# Altium schematic sheet SDCard.SchDoc(SDCard)
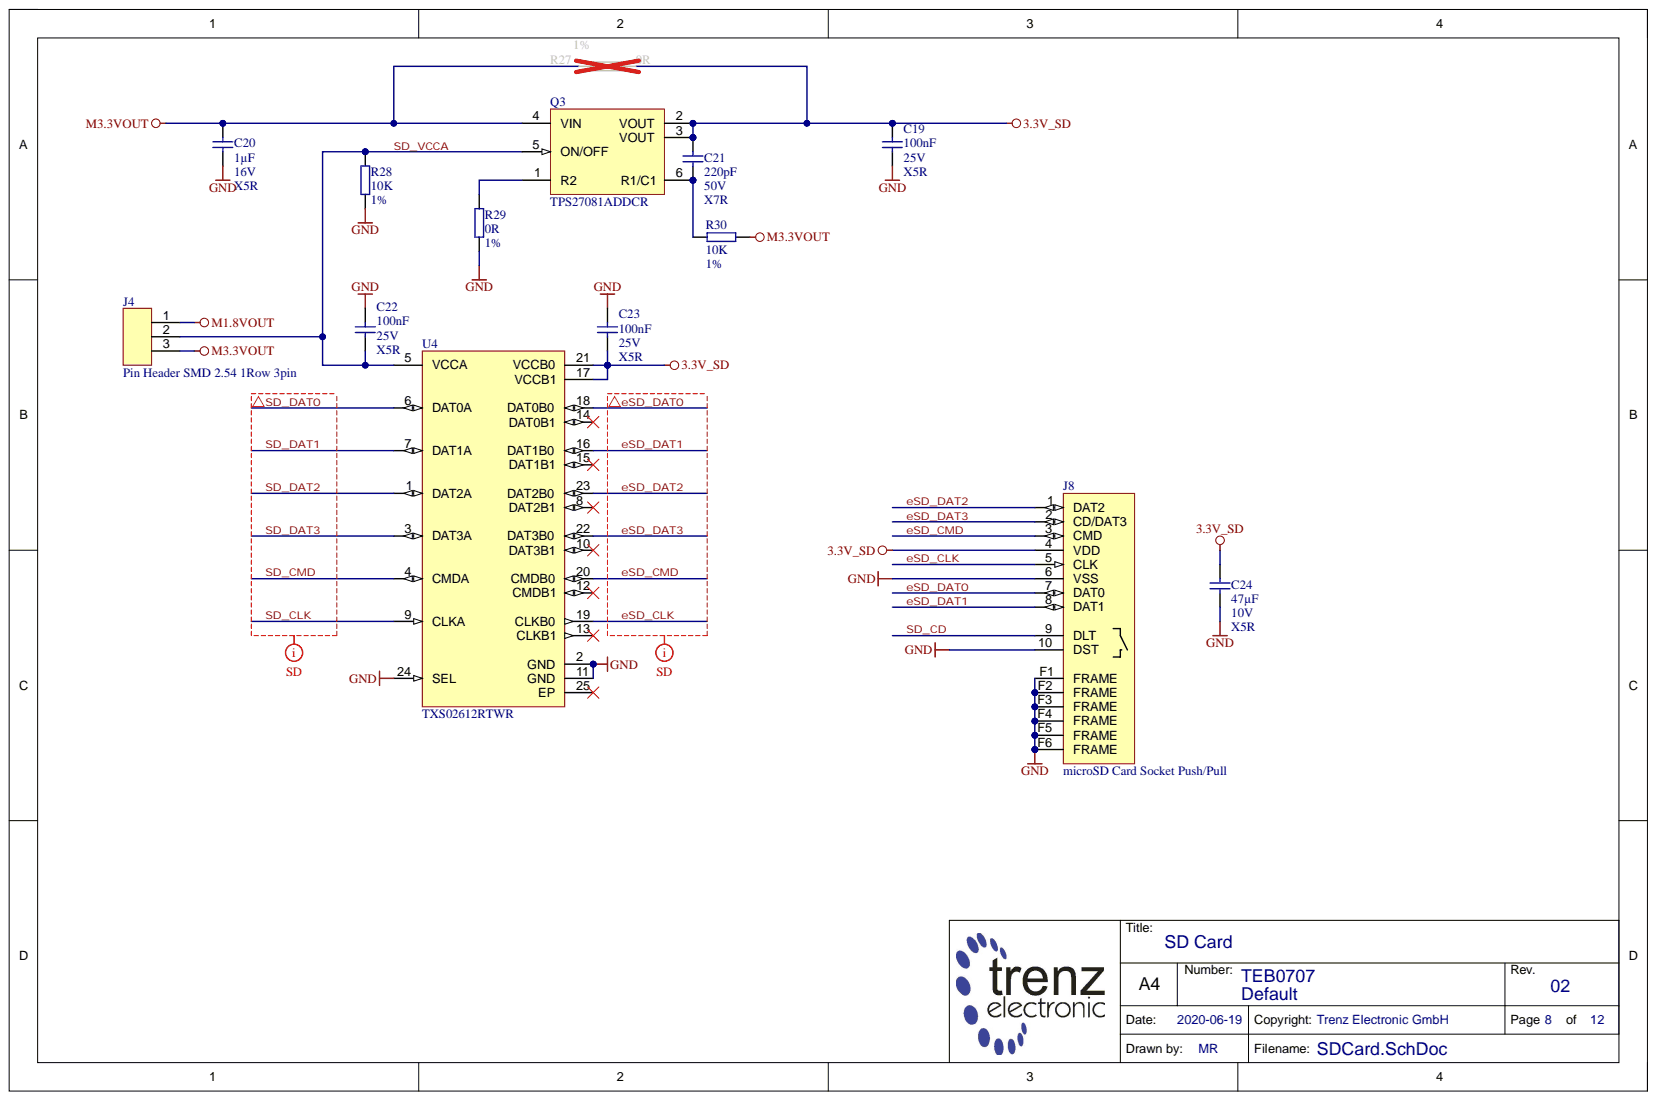
[diagram p0: SDCard.SchDoc]

## Parts
C19  100nF  Technology: X5R  Voltage: 25V  [CAPC1005X55N]
C20  1µF  Technology: X5R  Voltage: 16V  [CAPC1005X55N_V]
C21  220pF  Voltage: 50V  [CAPC1005X55N]
C22  100nF  Technology: X5R  Voltage: 25V  [CAPC1005X55N]
C23  100nF  Technology: X5R  Voltage: 25V  [CAPC1005X55N]
C24  47µF  Technology: X5R  Voltage: 10V  [CAPC2012X135N]
J4  Pin Header SMD 2.54 1Row 3pin  [TSM-103-01-F-SV]
J8  microSD Card Socket Push/Pull  Case: microSD  [504077-1891]
Q3  TPS27081ADDCR  [SOT95P280X110-6N]
R27  0R  Tolerance: 1%  [RESC2012X60N]
R28  10K  Tolerance: 1%  [RESC1005X40N]
R29  0R  Tolerance: 1%  [RESC1005X40N]
R30  10K  Tolerance: 1%  [RESC1005X40N]
U4  TXS02612RTWR  [QFN50P400X400X80-25N-255]

## Nets
3.3V_SD: C19.2 C21.2 C23.1 C24.2 J8.4(VDD) Q3.2(VOUT) Q3.3(VOUT) R27.2 U4.17(VCCB1) U4.21(VCCB0)
GND: C19.1 C20.2 C22.2 C23.2 C24.1 J8.6(VSS) J8.10(DST) J8.F1(FRAME) J8.F2(FRAME) J8.F3(FRAME) J8.F4(FRAME) J8.F5(FRAME) J8.F6(FRAME) R28.2 R29.1 U4.2(GND) U4.11(GND) U4.24(SEL)
M1.8VOUT: J4.1
M3.3VOUT: C20.1 J4.3 Q3.4(VIN) R27.1 R30.2
eSD_CLK: J8.5(CLK) U4.19(CLKB0)
eSD_CMD: J8.3(CMD) U4.20(CMDB0)
eSD_DAT0: J8.7(DAT0) U4.18(DAT0B0)
eSD_DAT1: J8.8(DAT1) U4.16(DAT1B0)
eSD_DAT2: J8.1(DAT2) U4.23(DAT2B0)
eSD_DAT3: J8.2(CD/DAT3) U4.22(DAT3B0)
SD_CD: J8.9(DLT)
SD_CLK: U4.9(CLKA)
SD_CMD: U4.4(CMDA)
SD_DAT0: U4.6(DAT0A)
SD_DAT1: U4.7(DAT1A)
SD_DAT2: U4.1(DAT2A)
SD_DAT3: U4.3(DAT3A)
SD_VCCA: C22.1 J4.2 Q3.5(ON/OFF) R28.1 U4.5(VCCA)
NetC21_1: C21.1 Q3.6(R1/C1) R30.1
NetQ3_1: Q3.1(R2) R29.2

Not connected: U4 8(DAT2B1) 10(DAT3B1) 12(CMDB1) 13(CLKB1) 14(DAT0B1) 15(DAT1B1) 25(EP)

## Library data of the parts
C19 C22 C23 = 22472: KON 100nF 25V X5R ±10% SMD BF 1005 (0402); Database Table Name: C_1005_0402; Mixed: SMT; Preferred: Yes; Temperature-Range: -55/+85; Value: 100n; AN: 22472; Case: 1005 (0402); Component Kind: Standard; Library Name: TE.DbLib
C20 = 26579: KON 1µF 16V X5R 10% SMD BF 1005 (0402); Database Table Name: C_1005_0402; Mixed: SMT; Temperature-Range: -55/+85; Value: 1u; AN: 26579; Case: 1005 (0402); Component Kind: Standard; Library Name: TE.DbLib; Tolerance: 10%
C21 = 24207: KON 220pF 50V X7R 10%  SMD BF 1005 (0402); Database Table Name: C_1005_0402; Mixed: SMT; Preferred: Yes; Temperature-Range: -55/+125; Value: 220p; AN: 24207; Case: 1005 (0402); Component Kind: Standard; Library Name: TE.DbLib; Technology: X7R; Tolerance: 10%
C24 = 26515: KON 47µF 10V  X5R 20% SMD BF 2012 (0805); Database Table Name: C_2012_0805; Mixed: SMT; Temperature-Range: -55/+85; Value: 47u; AN: 26515; Case: 2012 (0805); Component Kind: Standard; Library Name: TE.DbLib
J4 = 29447: Stiftleiste 1-reihig, 3pol. SMD; Database Table Name: CON_HEADER; Extra: 2,54mm, 5.84mm pin length, gold flash, PP pad; H-ART: TSM-103-01-F-SV-P-TR; Mixed: SMT; Temperature-Range: -55/+125; AN: 29447; Case: TSM; Component Kind: Standard; Library Name: TE.DbLib; HelpURL: b:\AD\adlib\datasheet\Samtec_TSM\
J8 = 29290: microSD Card Socket with Detect Switch; Extra: Molex 504077-1891; Mixed: SMT; Temperature-Range: -40/+85; AN: 29290; Component Kind: Standard; Database Table Name: SOCKET; H-ART: 504077-1891; Library Name: TE.DbLib; HelpURL: b:\AD\adlib\datasheet\504077-1891\
Q3 = 29262: Database Table Name: SWITCH; Extra: 1.2V - 8V, 3A PFET High-Side Load Switch; Mixed: SMT; Temperature-Range: -40/+85; AN: 29262; Case: SOT23-6; Component Kind: Standard; Description: TPS27081ADDCR; H-ART: TPS27081ADDCR; Library Name: TE.DbLib; HelpURL: b:\AD\adlib\datasheet\TPS27081A\
R27 = 23415: WID SMD 0R 0.125W 2A Brücke; Database Table Name: R_2012_0805; Extra: BF 2012 (0805); Mixed: SMT; Preferred: Yes; Value: 0; AN: 23415; Case: 2012 (0805); Component Kind: Standard; Library Name: TE.DbLib; Technology: TK100
R28 R30 = 22750: WID SMD 10K 1% 0,063W BF 1005 (0402); Database Table Name: R_1005_0402; H-ART: RC0402FR-0710KL; Mixed: SMT; Preferred: Yes; AN: 22750; Case: 1005 (0402); Component Kind: Standard; Library Name: TE.DbLib; Technology: TK100; Value: 10K
R29 = 22738: WID SMD 0R  BF 1005 (0402); Database Table Name: R_1005_0402; H-ART: RC0402JR-070RL; Mixed: SMT; Preferred: Yes; Value: 0; AN: 22738; Case: 1005 (0402); Component Kind: Standard; Library Name: TE.DbLib; Technology: TK100
U4 = 24904: Database Table Name: IF; Extra: SDIO Port Expander With Voltage-Level Translation; Mixed: SMT; Trenz-Stock: Yes; AN: 24904; Case: QFN24; Component Kind: Standard; Description: TXS02612RTWR; H-ART: TXS02612RTWR; Library Name: TE.DbLib; HelpURL: B:\AD\adlib\datasheet\TXS02612
